# Altium schematic sheet LED.SchDoc
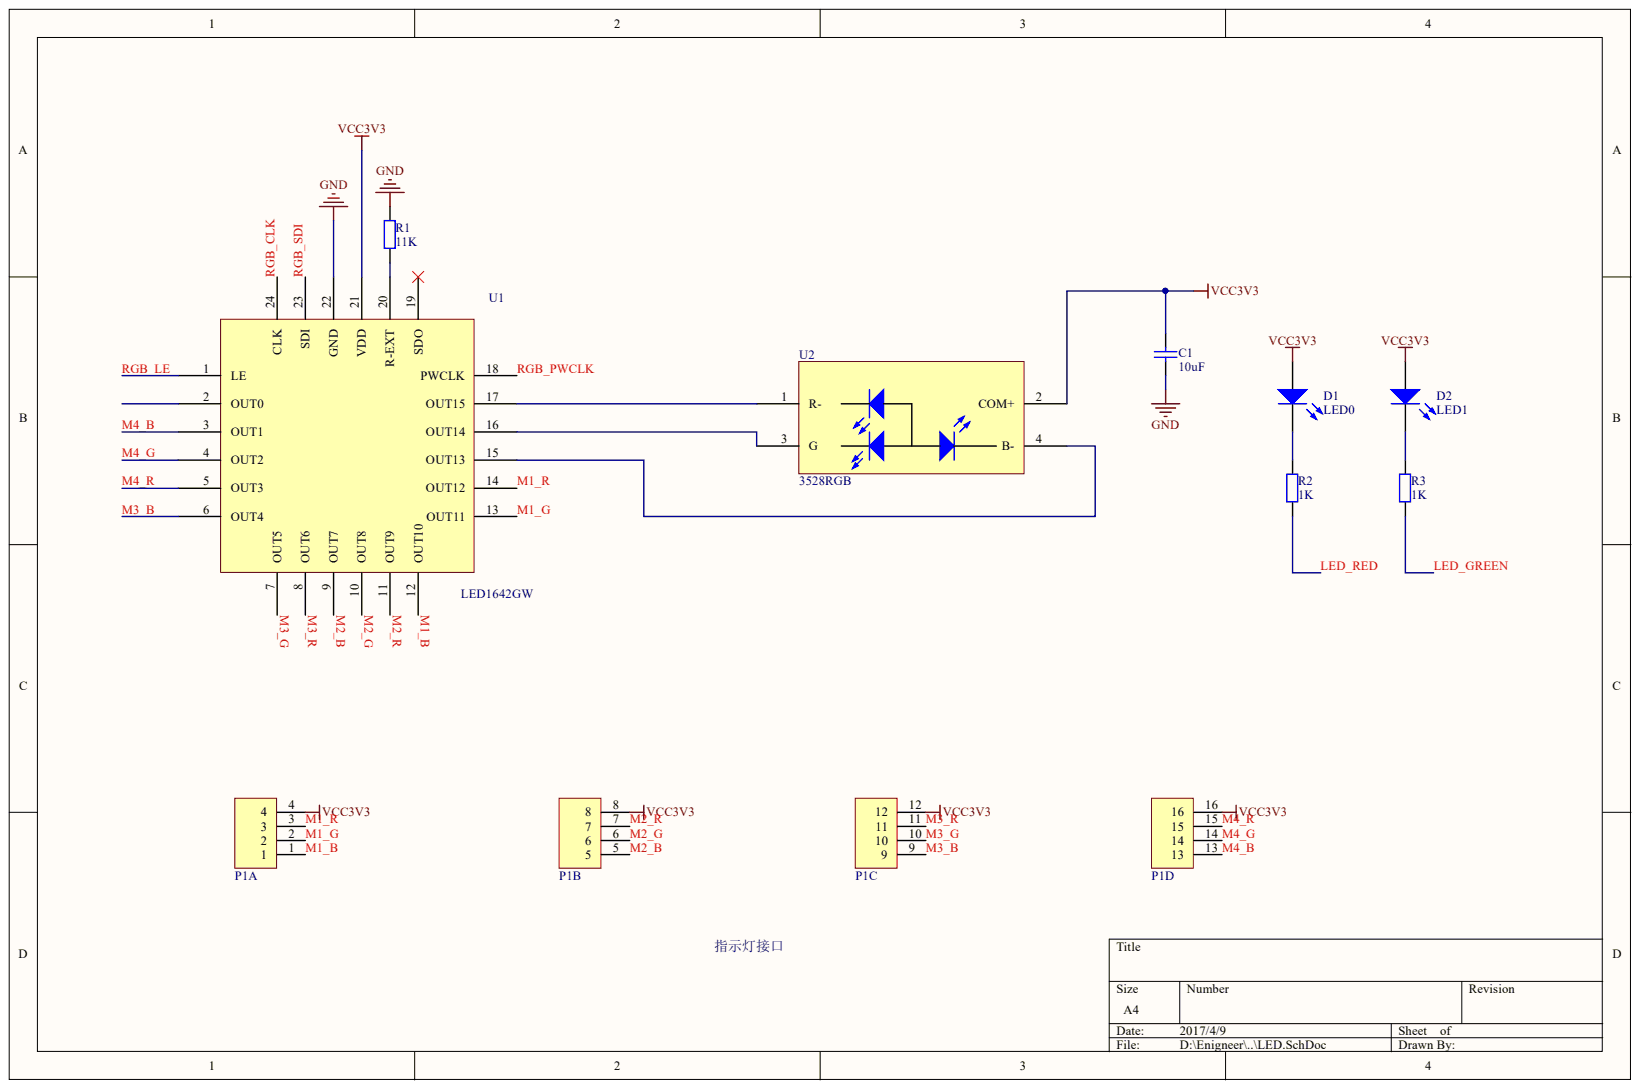
[diagram p0: LED.SchDoc]

## Parts
C1  value 10uF  [0603C]  (hidden comment: Cap)
D1  LED0  [Diode-0805]
D2  LED1  [Diode-0805]
P1  Header 4A  [HDR4*4]  (value not drawn)  (parts A,B,C,D)
R1  value 11K  [0603R]  (hidden comment: Res2)
R2  value 1K  [0603R]  (hidden comment: Res2)
R3  value 1K  [0603R]  (hidden comment: Res2)
U1  LED1642GW  [LED1642GW-QFN]
U2  3528RGB  [3528RGB]

## Nets
GND: C1.2 R1.2 U1.22(GND)
VCC3V3: C1.1 D1.1 D2.1 P1.4 P1.8 P1.12 P1.16 U1.21(VDD) U2.2(COM+)
LED_GREEN: R3.2
LED_RED: R2.2
M1_B: P1.1 U1.12(OUT10)
M1_G: P1.2 U1.13(OUT11)
M1_R: P1.3 U1.14(OUT12)
M2_B: P1.5 U1.9(OUT7)
M2_G: P1.6 U1.10(OUT8)
M2_R: P1.7 U1.11(OUT9)
M3_B: P1.9 U1.6(OUT4)
M3_G: P1.10 U1.7(OUT5)
M3_R: P1.11 U1.8(OUT6)
M4_B: P1.13 U1.3(OUT1)
M4_G: P1.14 U1.4(OUT2)
M4_R: P1.15 U1.5(OUT3)
RGB_CLK: U1.24(CLK)
RGB_LE: U1.1(LE)
RGB_PWCLK: U1.18(PWCLK)
RGB_SDI: U1.23(SDI)
NetD1_2: D1.2 R2.1
NetD2_2: D2.2 R3.1
NetR1_1: R1.1 U1.20(R-EXT)
NetU1_15: U1.15(OUT13) U2.4(B-)
NetU1_16: U1.16(OUT14) U2.3(G)
NetU1_17: U1.17(OUT15) U2.1(R-)

Not connected: U1 2(OUT0) 19(SDO)

## Library data of the parts
C1 = Cap: Capacitor; Component Kind: Standard; LatestRevisionDate: 17-Jul-2002; LatestRevisionNote: Re-released for DXP Platform.; Library Name: Miscellaneous Devices.IntLib; PackageDescription: Radial Cap, Thru-Hole; 2 Leads; 0.3 in Pin Spacing; PackageReference: RAD-0.3; Published: 8-Jun-2000; Signal Integrity: Cap; Simulation: CAP; Publisher: Altium Limited
D1 = LED0: Typical INFRARED GaAs LED; Component Kind: Standard; LatestRevisionDate: 08-Jul-2005; LatestRevisionNote: Mech Layer 1 removed.; Library Name: Miscellaneous Devices.IntLib; PackageDescription: LED; 2 Leads; PackageReference: LED-0; Published: 8-Jun-2000; Simulation: LED0; Publisher: Altium Limited
D2 = LED1: Typical RED GaAs LED; Component Kind: Standard; LatestRevisionDate: 08-Jul-2005; LatestRevisionNote: Mech Layer 1 removed.; Library Name: Miscellaneous Devices.IntLib; PackageDescription: LED; 2 Leads; PackageReference: LED-1; Published: 8-Jun-2000; Simulation: LED1; Publisher: Altium Limited
P1 = Header 4: 接插件; Component Kind: Standard; LatestRevisionDate: 17-Jul-2002; LatestRevisionNote: Re-released for DXP Platform.; Library Name: pxLib.SchLib; Publisher: Altium Limited
R1-R3 = Res2: Resistor; Component Kind: Standard; LatestRevisionDate: 17-Jul-2002; LatestRevisionNote: Re-released for DXP Platform.; Library Name: Miscellaneous Devices.IntLib; PackageDescription: Axial Device, Thru-Hole; 2 Leads; 0.4 in Pin Spacing; PackageReference: AXIAL-0.4; Published: 8-Jun-2000; Signal Integrity: Res; Simulation: RESISTOR; Publisher: Altium Limited
U1 = LED1642: Component Kind: Standard; Library Name: pxLib.SchLib
U2 = 3528RGB: Component Kind: Standard; Library Name: pxLib.SchLib
